# Altium schematic sheet STM32F103_CORE.SchDoc(STM32F103_CORE)
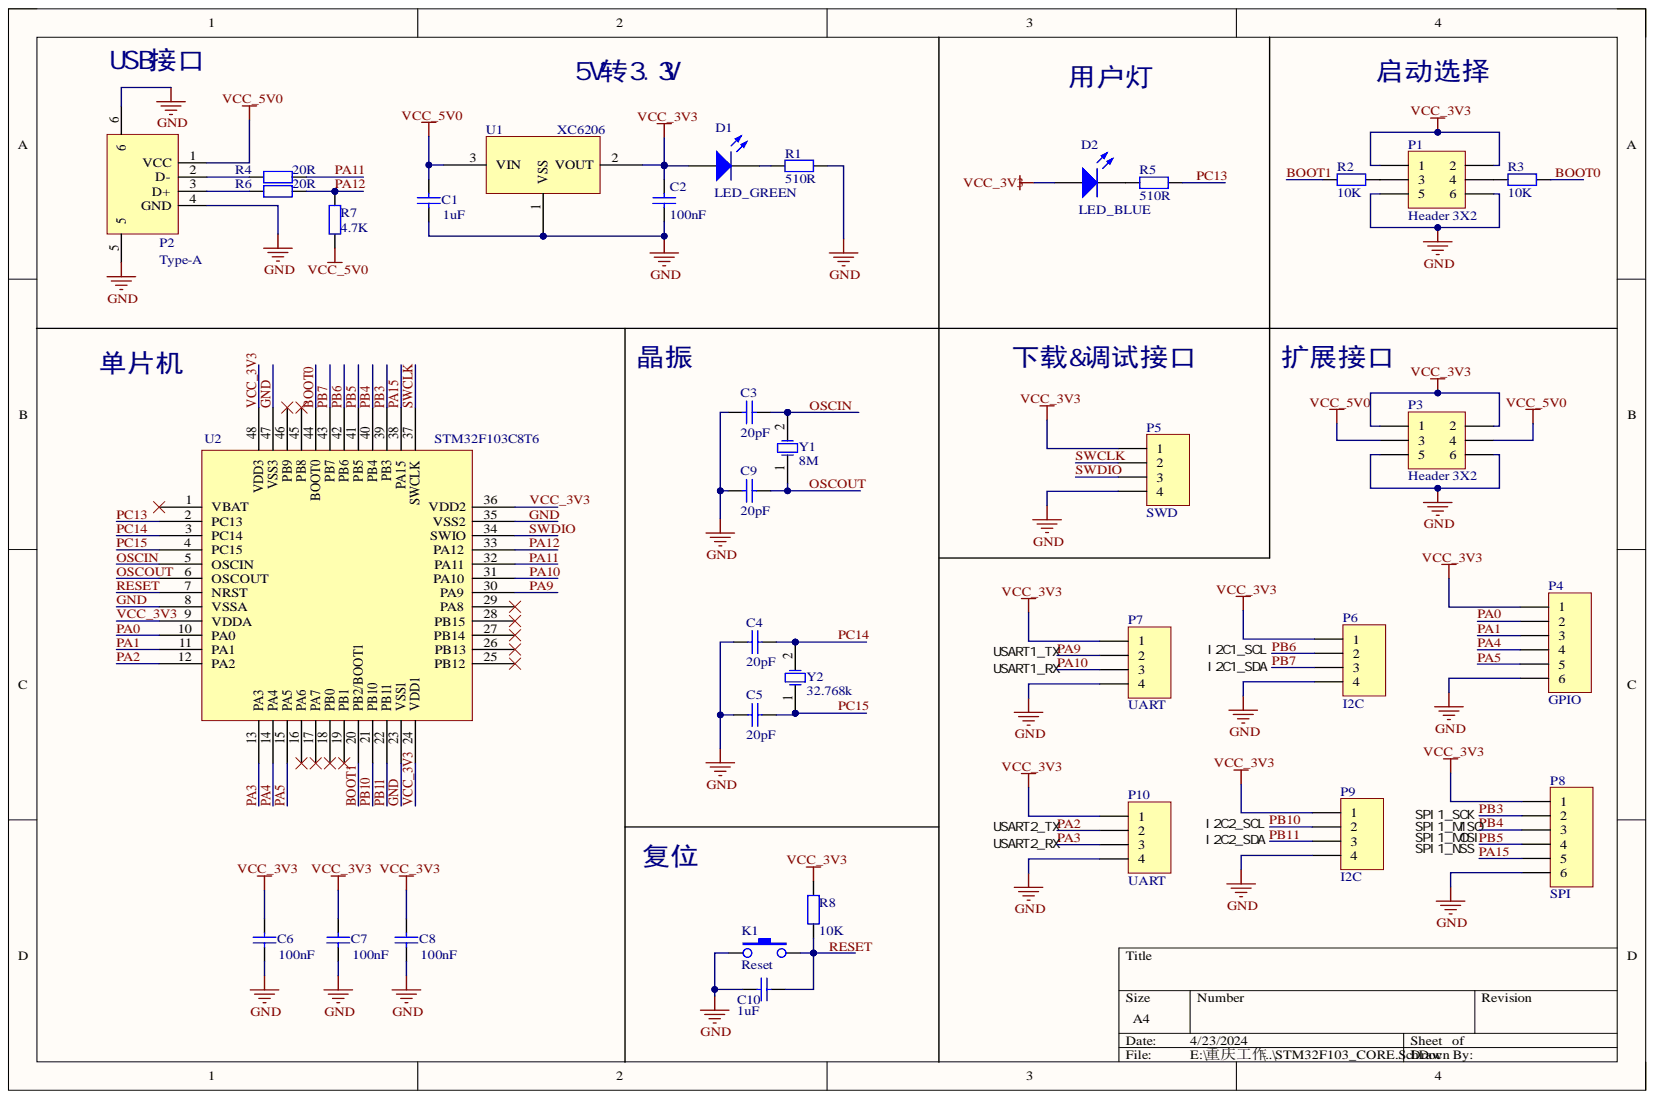
[diagram p0: STM32F103_CORE.SchDoc]

## Parts
C1  value 1uF  [0603C]  (hidden comment: 105)
C2  value 100nF  [0603C]  (hidden comment: 104)
C3  20pF  [0603C]
C4  20pF  [0603C]
C5  20pF  [0603C]
C6  value 100nF  [0603C]  (hidden comment: 104)
C7  value 100nF  [0603C]  (hidden comment: 104)
C8  value 100nF  [0603C]  (hidden comment: 104)
C9  20pF  [0603C]
C10  value 1uF  [0603C]  (hidden comment: 105)
D1  LED_GREEN  [0603_LED]
D2  LED_BLUE  [0603_LED]
K1  Reset  [KEY_3A5X2A5]
P1  Header 3X2  [HDR2X3]
P2  Type-A  [USB]
P3  Header 3X2  [HDR2X3]
P4  GPIO  [HDR1X6]
P5  SWD  [HDR1X4]
P6  I2C  [HDR1X4]
P7  UART  [HDR1X4]
P8  SPI  [HDR1X6]
P9  I2C  [HDR1X4]
P10  UART  [HDR1X4]
R1  510R  [0603R]
R2  10K  [0603R]
R3  10K  [0603R]
R4  20R  [0603R]
R5  510R  [0603R]
R6  20R  [0603R]
R7  4.7K  [0603R]
R8  10K  [0603R]
U1  XC6206  [SOT23-3M]
U2  STM32F103C8T6  [LQFP48 7X7_M]
Y1  8M  [HC49无源晶振]
Y2  32.768k  [DT-26]

## Nets
VCC_5V0: C1.2 P2.1(VCC) P3.3 P3.4 R7.2 U1.3
BOOT0: R3.1 U2.44(BOOT0)
BOOT1: R2.2 U2.20(PB2/BOOT1)
GND: C1.1 C2.1 C3.2 C4.2 C5.2 C6.1 C7.1 C8.1 C9.2 C10.2 K1.1 P1.5 P1.6 P2.4(GND) P2.5 P2.6 P3.5 P3.6 P4.6 P5.4 P6.4 P7.4 P8.6 P9.4 P10.4 R1.1 U1.1 U2.8(VSSA) U2.23(VSS1) U2.35(VSS2) U2.47(VSS3)
OSCIN: C3.1 U2.5(OSCIN) Y1.2
OSCOUT: C9.1 U2.6(OSCOUT) Y1.1
PA0: P4.2 U2.10(PA0)
PA1: P4.3 U2.11(PA1)
PA2: P10.2 U2.12(PA2)
PA3: P10.3 U2.13(PA3)
PA4: P4.4 U2.14(PA4)
PA5: P4.5 U2.15(PA5)
PA9: P7.2 U2.30(PA9)
PA10: P7.3 U2.31(PA10)
PA11: R4.1 U2.32(PA11)
PA12: R6.1 R7.1 U2.33(PA12)
PA15: P8.5 U2.38(PA15)
PB3: P8.2 U2.39(PB3)
PB4: P8.3 U2.40(PB4)
PB5: P8.4 U2.41(PB5)
PB6: P6.2 U2.42(PB6)
PB7: P6.3 U2.43(PB7)
PB10: P9.2 U2.21(PB10)
PB11: P9.3 U2.22(PB11)
PC13: R5.1 U2.2(PC13)
PC14: C4.1 U2.3(PC14) Y2.2
PC15: C5.1 U2.4(PC15) Y2.1
RESET: C10.1 K1.2 R8.1 U2.7(NRST)
SWCLK: P5.2 U2.37(SWCLK)
SWDIO: P5.3 U2.34(SWIO)
VCC_3V3: C2.2 C6.2 C7.2 C8.2 D1.1 D2.1 P1.1 P1.2 P3.1 P3.2 P4.1 P5.1 P6.1 P7.1 P8.1 P9.1 P10.1 R8.2 U1.2 U2.9(VDDA) U2.24(VDD1) U2.36(VDD2) U2.48(VDD3)
NetD1_2: D1.2 R1.2
NetD2_2: D2.2 R5.2
NetP1_3: P1.3 R2.1
NetP1_4: P1.4 R3.2
NetP2_2: P2.2(D-) R4.2
NetP2_3: P2.3(D+) R6.2

Not connected: U2 1(VBAT) 16(PA6) 17(PA7) 18(PB0) 19(PB1) 25(PB12) 26(PB13) 27(PB14) 28(PB15) 29(PA8) 45(PB8) 46(PB9)

## Library data of the parts
C1-C9 = Cap: Capacitor; Component Kind: Standard; LatestRevisionDate: 17-Jul-2002; LatestRevisionNote: Re-released for DXP Platform.; Library Name: Miscellaneous Devices.IntLib; PackageDescription: Radial Cap, Thru-Hole; 2 Leads; 0.3 in Pin Spacing; PackageReference: RAD-0.3; Published: 8-Jun-2000; Signal Integrity: Cap; Simulation: CAP; Publisher: Altium Limited
  C3-C5 C9: Value: 20pF
C10 = Cap: Component Kind: Standard; Description: 105; LatestRevisionDate: 17-Jul-2002; LatestRevisionNote: Re-released for DXP Platform.; Library Name: Miscellaneous Devices.IntLib; PackageDescription: Radial Cap, Thru-Hole; 2 Leads; 0.3 in Pin Spacing; PackageReference: RAD-0.3; Published: 8-Jun-2000; Signal Integrity: Cap; Simulation: CAP; Publisher: Altium Limited
D1 D2 = LED0: Typical INFRARED GaAs LED; Component Kind: Standard; LatestRevisionDate: 08-Jul-2005; LatestRevisionNote: Mech Layer 1 removed.; Library Name: Miscellaneous Devices.IntLib; PackageDescription: LED; 2 Leads; PackageReference: LED-0; Published: 8-Jun-2000; Simulation: LED0; Publisher: Altium Limited
K1 = KEY: Component Kind: Standard; Description: KEY; Library Name: STM32F103C8T6.SCHLIB
P1 P3 = Header 3X2: Header, 3-Pin, Dual row; Component Kind: Standard; LatestRevisionDate: 17-Jul-2002; LatestRevisionNote: Re-released for DXP Platform.; Library Name: Miscellaneous Connectors.IntLib; Signal Integrity: Connector; Publisher: Altium Limited
P2 = USB: USB 2.0公头 C404965; Component Kind: Standard; Library Name: STM32F103_CORE.SchLib
P4 P8 = Header 6: Header, 6-Pin; Component Kind: Standard; LatestRevisionDate: 17-Jul-2002; LatestRevisionNote: Re-released for DXP Platform.; Library Name: Miscellaneous Connectors.IntLib; Signal Integrity: Connector; Publisher: Altium Limited
P5-P7 P9 P10 = Header 4: SH1.25-4P; Component Kind: Standard; LatestRevisionDate: 17-Jul-2002; LatestRevisionNote: Re-released for DXP Platform.; Library Name: Miscellaneous Connectors.IntLib; Signal Integrity: Connector; Publisher: Altium Limited
R1-R8 = Res2: Resistor; Component Kind: Standard; LatestRevisionDate: 17-Jul-2002; LatestRevisionNote: Re-released for DXP Platform.; Library Name: Miscellaneous Devices.IntLib; PackageDescription: Axial Device, Thru-Hole; 2 Leads; 0.4 in Pin Spacing; PackageReference: AXIAL-0.4; Published: 8-Jun-2000; Signal Integrity: Res; Simulation: RESISTOR; Publisher: Altium Limited
  R1 R5: Value: 510R
  R2 R3 R8: Value: 10K
  R4 R6: Value: 20R
  R7: Value: 4.7K
Y1 Y2 = XTAL: Crystal Oscillator; Component Kind: Standard; LatestRevisionDate: 17-Jul-2002; LatestRevisionNote: Re-released for DXP Platform.; Library Name: Miscellaneous Devices.IntLib; PackageDescription: Crystal, Thru-Hole; 2 Leads; Body 3.1 x 8.2 mm (Dia.xH); PackageReference: R38; Published: 8-Jun-2000; Simulation: XTAL; Publisher: Altium Limited
U1 = XC6206: Component Kind: Standard; Library Name: Schlib1.SchLib
U2 = STM32F103C8T6: Component Kind: Standard; Library Name: Schlib1.SchLib
